# Altium schematic sheet Accelerometre.SchDoc
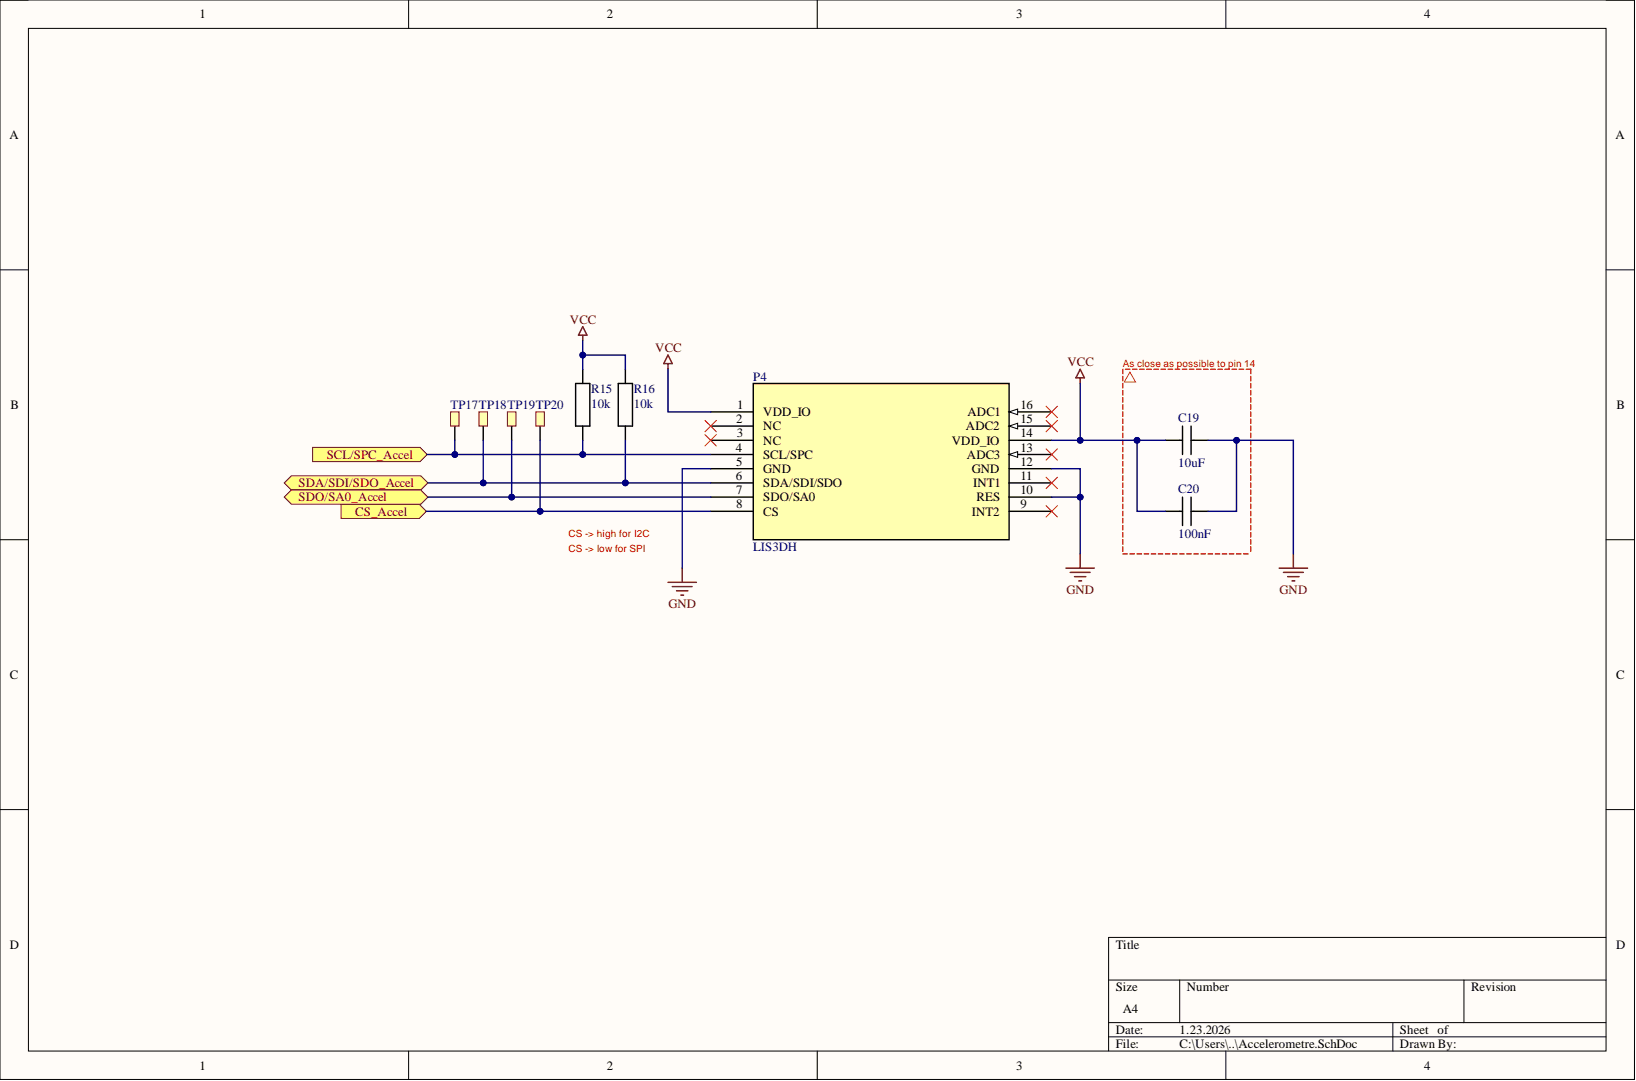
[diagram p0: Accelerometre.SchDoc]

## Parts
C19  10uF  [CAPC1608X08M]
C20  100nF  [CAPC1608X08M]
P4  LIS3DH  [LGA-16_3x3x1mm_STM]
R15  10k  [RESC1608X06M]
R16  10k  [RESC1608X06M]
TP17  5019  [TESTPOINT_SMD]  (value not drawn)
TP18  5019  [TESTPOINT_SMD]  (value not drawn)
TP19  5019  [TESTPOINT_SMD]  (value not drawn)
TP20  5019  [TESTPOINT_SMD]  (value not drawn)

## Ports
CS_Accel, SCL/SPC_Accel, SDA/SDI/SDO_Accel, SDO/SA0_Accel

## Nets
GND: C19.2 C20.2 P4.5(GND) P4.10(RES) P4.12(GND)
VCC: C19.1 C20.1 P4.1(VDD_IO) P4.14(VDD_IO) R15.2 R16.2
CS_Accel: P4.8(CS) TP20.1 ; port CS_Accel
SCL/SPC_Accel: P4.4(SCL/SPC) R15.1 TP17.1 ; port SCL/SPC_Accel
SDA/SDI/SDO_Accel: P4.6(SDA/SDI/SDO) R16.1 TP18.1 ; port SDA/SDI/SDO_Accel
SDO/SA0_Accel: P4.7(SDO/SA0) TP19.1 ; port SDO/SA0_Accel

Not connected: P4 2(NC) 3(NC) 9(INT2) 11(INT1) 13(ADC3) 15(ADC2) 16(ADC1)

## Library data of the parts
C19 = CMP-065-000003-2: CAPC 10uF X5R 10V 20% 1608 (0603 INCH); Manufacturer: TDK; Manufacturer Number: C1608X5R1A106M080AC; IsStocked: 1; PN: PN-131657; TemperatureRange: -55°C to +85°C; Value: 10µF; Category: Passives; Component Kind: Standard; Dielectric: X5R; Library Name: ETML-ES; SubCategory: Condensateurs ceramique; Supplier 1: ETML; Supplier Part Number 1: FAB.0603_C 10uF; Supplier Price 1: 0.0944; Tolerance: ±20%; Voltage: 10V
C20 = CMP-065-000000-2: CAPC 100nF X7R 50V 10% 1608 (0603 INCH); Manufacturer: TDK; Manufacturer Number: CGA3E2X7R1H104K080AA; Current: NULL; IsStocked: 1; PN: PN-338083; TemperatureRange: -55°C to +125°C; Category: Passives; Component Kind: Standard; Dielectric: X7R; Library Name: ETML-ES; Power: NULL; SubCategory: Condensateurs ceramique; Supplier 1: ETML; Supplier Part Number 1: FAB.0603_C 100n; Supplier Price 1: 0.0100; TemperatureCoeff: NULL; Tolerance: ±10%; Value: 100nF; Voltage: 50V
P4 = LIS3DH: 3-axis MEMS accelerometer, ultra-low-power,  &#177;2g/&#177;4g/&#177;8g/&#177;16g full scale, high-speed I2C/SPI digital output, embedded FIFO, high-performance acceleration sensor, LLGA 16 3x3x1.0 package; Manufacturer_Name: STMicroelectronics; Component Kind: Standard; Library Name: Schlib1.SchLib; Manufacturer_Part_Number: LIS3DH; RefDes: RefDes; Type: Type; Copyright: Copyright (C) 2025 Ultra Librarian. All rights reserved.
R15 R16 = CMP-066-000124-2: RES 10kohm  ±1% 0.1W  1608 (0603 INCH); Manufacturer: Yageo; Manufacturer Number: RC0603FR-0710KL; IsStocked: 1; PN: PN-896786; TemperatureCoeff: ±100ppm/°C; TemperatureRange: -55°C to 155°C; Voltage: 75V; Category: Passives; Component Kind: Standard; Library Name: ETML-ES; Power: 0.1W; SubCategory: Resistances couche epaisse; Supplier 1: ETML; Supplier Part Number 1: FAB.0603_R 10k; Supplier Price 1: 0.0200; Tolerance: ±1%; Value: 10k
TP17-TP20 = TESTPOINT: Test point smd; Component Kind: Standard; Supplier 1: Mouser; Supplier Part Number 1: 534-5019; Library Name: 2008_SablierElectronique_SCHLIB.SchLib
